# Altium schematic sheet 05 DO.SchDoc
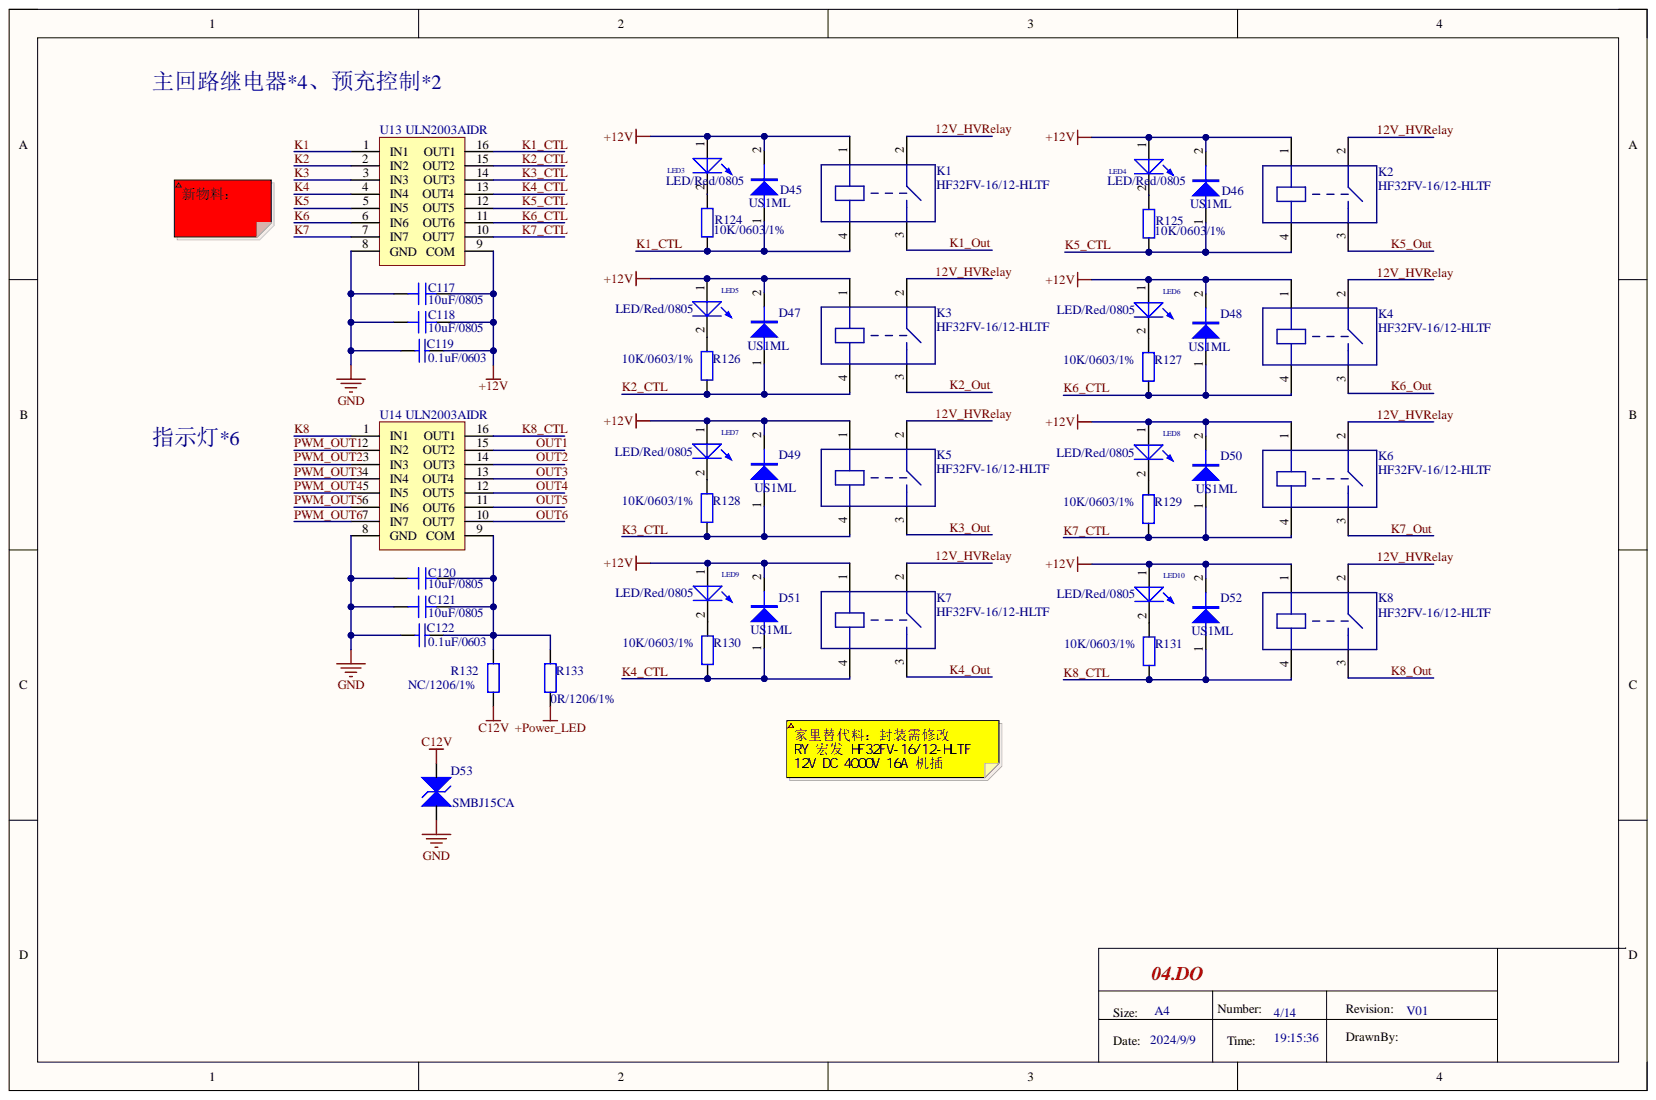
[diagram p0: 05 DO.SchDoc]

## Parts
C117  10uF/0805  [C1206]
C118  10uF/0805  [C1206]
C119  0.1uF/0603  [C0603]
C120  10uF/0805  [C1206]
C121  10uF/0805  [C1206]
C122  0.1uF/0603  [C0603]
D45  US1ML  [SOD123]
D46  US1ML  [SOD123]
D47  US1ML  [SOD123]
D48  US1ML  [SOD123]
D49  US1ML  [SOD123]
D50  US1ML  [SOD123]
D51  US1ML  [SOD123]
D52  US1ML  [SOD123]
D53  SMBJ15CA  [SMB]
K1  HF32FV-16/12-HLTF  [HF32FV-16]
K2  HF32FV-16/12-HLTF  [HF32FV-16]
K3  HF32FV-16/12-HLTF  [HF32FV-16]
K4  HF32FV-16/12-HLTF  [HF32FV-16]
K5  HF32FV-16/12-HLTF  [HF32FV-16]
K6  HF32FV-16/12-HLTF  [HF32FV-16]
K7  HF32FV-16/12-HLTF  [HF32FV-16]
K8  HF32FV-16/12-HLTF  [HF32FV-16]
LED3  LED/Red/0805  [D0805]
LED4  LED/Red/0805  [D0805]
LED5  LED/Red/0805  [D0805]
LED6  LED/Red/0805  [D0805]
LED7  LED/Red/0805  [D0805]
LED8  LED/Red/0805  [D0805]
LED9  LED/Red/0805  [D0805]
LED10  LED/Red/0805  [D0805]
R124  10K/0603/1%  [R0603]
R125  10K/0603/1%  [R0603]
R126  10K/0603/1%  [R0603]
R127  10K/0603/1%  [R0603]
R128  10K/0603/1%  [R0603]
R129  10K/0603/1%  [R0603]
R130  10K/0603/1%  [R0603]
R131  10K/0603/1%  [R0603]
R132  0R/1206/1%  [R1206]  (value not drawn)
R133  NC/1206/1%  [R1206]  (value not drawn)
U13  ULN2003AIDR  [SOIC-16_150MIL]
U14  ULN2003AIDR  [SOIC-16_150MIL]

## Nets
+Power_LED: R133.1
C12V: D53.1 R132.1
GND: C117.2 C118.2 C119.1 C120.2 C121.2 C122.1 D53.2 U13.8(GND) U14.8(GND)
12V_HVRelay: C117.1 C118.1 C119.2 D45.2 D46.2 D47.2 D48.2 D49.2 D50.2 D51.2 D52.2 K1.1 K1.2 K2.1 K2.2 K3.1 K3.2 K4.1 K4.2 K5.1 K5.2 K6.1 K6.2 K7.1 K7.2 K8.1 K8.2 LED3.1 LED4.1 LED5.1 LED6.1 LED7.1 LED8.1 LED9.1 LED10.1 U13.9(COM)
K1: U13.1(IN1)
K1_CTL: D45.1 K1.4 R124.1 U13.16(OUT1)
K1_Out: K1.3
K2: U13.2(IN2)
K2_CTL: D47.1 K3.4 R126.1 U13.15(OUT2)
K2_Out: K3.3
K3: U13.3(IN3)
K3_CTL: D49.1 K5.4 R128.1 U13.14(OUT3)
K3_Out: K5.3
K4: U13.4(IN4)
K4_CTL: D51.1 K7.4 R130.1 U13.13(OUT4)
K4_Out: K7.3
K5: U13.5(IN5)
K5_CTL: D46.1 K2.4 R125.1 U13.12(OUT5)
K5_Out: K2.3
K6: U13.6(IN6)
K6_CTL: D48.1 K4.4 R127.1 U13.11(OUT6)
K6_Out: K4.3
K7: U13.7(IN7)
K7_CTL: D50.1 K6.4 R129.1 U13.10(OUT7)
K7_Out: K6.3
K8: U14.1(IN1)
K8_CTL: D52.1 K8.4 R131.1 U14.16(OUT1)
K8_Out: K8.3
OUT1: U14.15(OUT2)
OUT2: U14.14(OUT3)
OUT3: U14.13(OUT4)
OUT4: U14.12(OUT5)
OUT5: U14.11(OUT6)
OUT6: U14.10(OUT7)
PWM_OUT1: U14.2(IN2)
PWM_OUT2: U14.3(IN3)
PWM_OUT3: U14.4(IN4)
PWM_OUT4: U14.5(IN5)
PWM_OUT5: U14.6(IN6)
PWM_OUT6: U14.7(IN7)
NetC120_1: C120.1 C121.1 C122.2 R132.2 R133.2 U14.9(COM)
NetLED3_2: LED3.2 R124.2
NetLED4_2: LED4.2 R125.2
NetLED5_2: LED5.2 R126.2
NetLED6_2: LED6.2 R127.2
NetLED7_2: LED7.2 R128.2
NetLED8_2: LED8.2 R129.2
NetLED9_2: LED9.2 R130.2
NetLED10_2: LED10.2 R131.2

## Library data of the parts
C117 C118 C120 C121 = 22uF_25V_10%_X7R_1210: 片状电容器 - 25V-10uF±10%-X5R; Component Kind: Standard; Library Name: Component Library.IntLib
C119 C122 = Cap: 无极性电容; 厂: Samsung; Component Kind: Standard; Library Name: 超超的封装库.IntLib
D45-D52 = SMT_1N4004: DIODE 长晶 US1ML 1000V 1A SOD-123FL 贴装; 品牌: 常州星海; Component Kind: Standard; Library Name: ZKM1V02.SCHLIB
D53 = Diode TVS: 双向TVS; 厂商: 君耀; 厂商编号: SMBJ20CA; Component Kind: Standard; Library Name: 超超的封装库.IntLib
K1-K8 = HF46F-G/12-HS1T: RY 宏发 HF32FV-16/12-HLTF 12V DC 4000V 16A 机插; 焊接类型: THT; Component Kind: Standard; ERP code: ELC.0178; Library Name: DH_Other_Standard.SchLib; 品牌: 宏发; 型号规格: HF32FV-16
LED3-LED10 = LED/Red/0603: LED灯珠,红色,150mcd,0805; 品牌: 亿晶源; 型号规格: E6C0603URAC1UDA; 焊接类型: SMT; Component Kind: Standard; ERP code: ELC.0228; Library Name: DH_Diode_Standard.SchLib
R124-R131 = 10K/0603/1%: 贴片电阻,10K-1/10W-0603-1%; 品牌: YAGEO; 型号规格: RC0603FR-0710KL; 焊接类型: SMT; Component Kind: Standard; ERP code: ELC.0270; Library Name: DH_Resistance_Standard.SchLib
R132 R133 = 10K/0603/1%: 贴片电阻,0R/1206/1%; 品牌: YAGEO; 型号规格: RC0603FR-0710KL; 焊接类型: SMT; Component Kind: Standard; ERP code: ELC.0270; Library Name: DH_Resistance_Standard.SchLib
U13 U14 = ULN2003AIDR: 达林顿晶体管 ,7路; 品牌: TI; 替换料: ELC.0585/ULN2003D1013TR/ST; 焊接类型: SMT; Component Kind: Standard; ERP Code: ELC.0501; Library Name: DH_Chip_Standard.SchLib; 型号规格: ULN2003AIDR
